# Altium schematic sheet Sheet1.SchDoc(Sheet1)
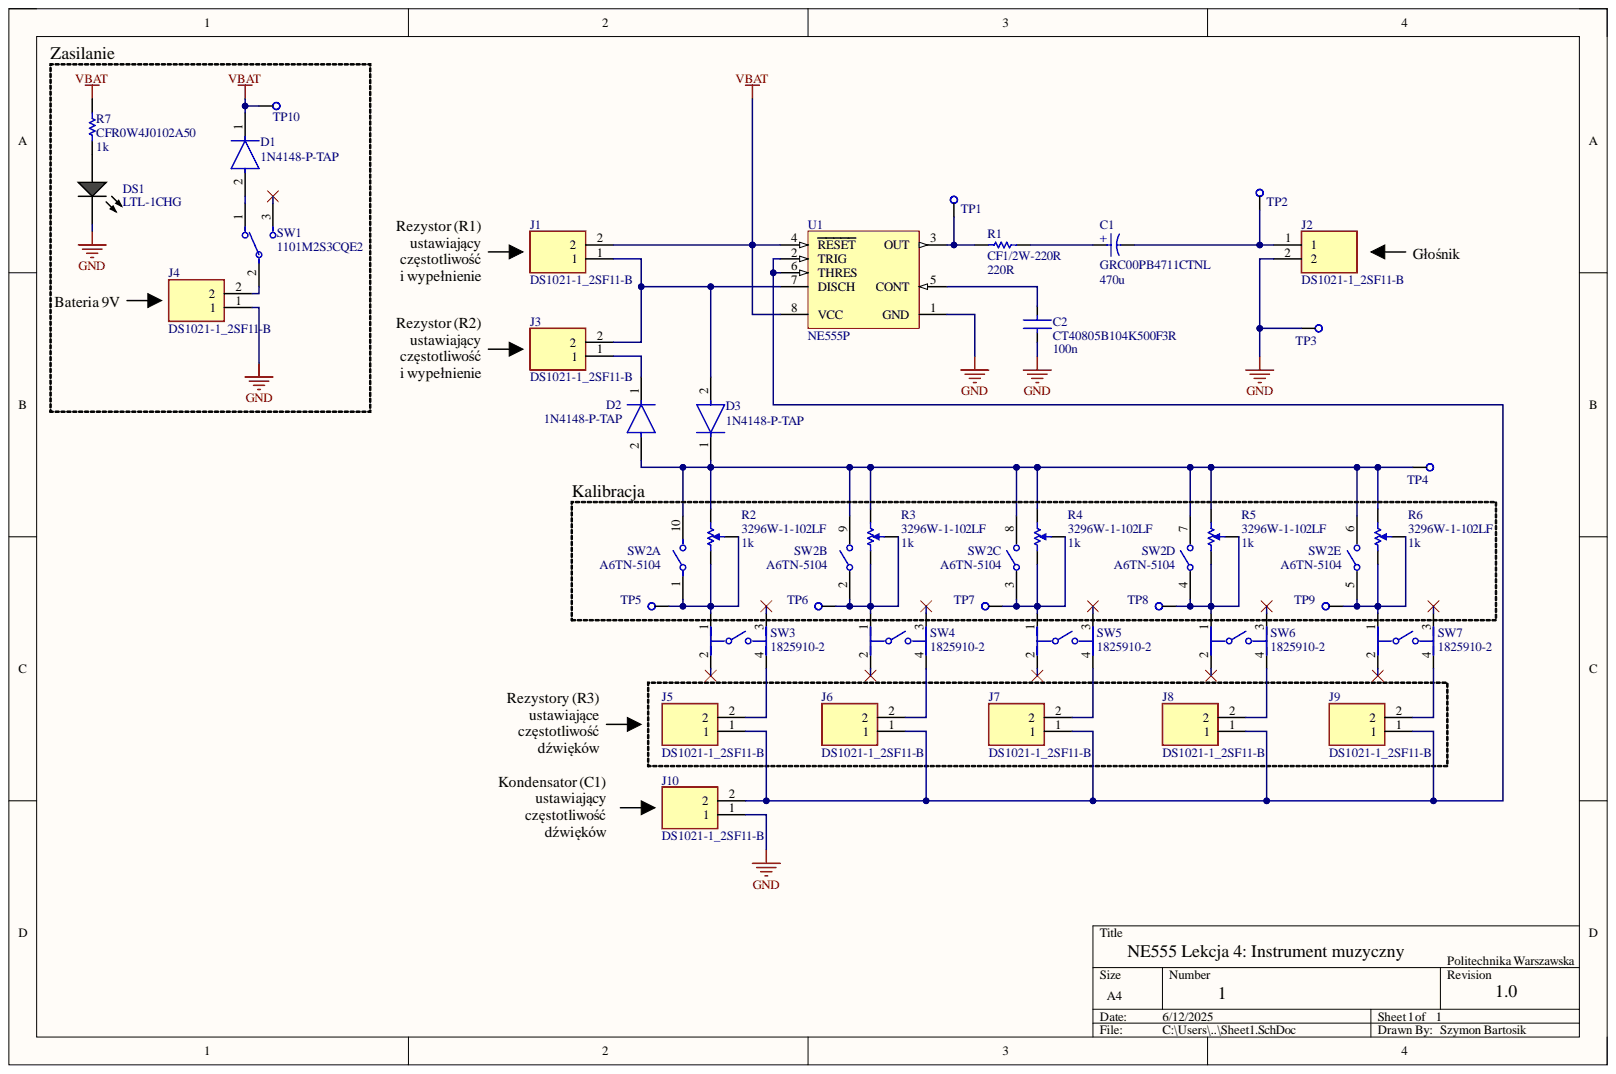
[diagram p0: Sheet1.SchDoc]

## Parts
C1  GRC00PB4711CTNL  Capacitance: 470u  [THT_Radial_Cap_D8.0mm_H11.5mm_P3.5mm]
C2  CT40805B104K500F3R  Capacitance: 100n  [THT_RADIAL_CAP_P5.0mm]
D1  1N4148-P-TAP  [FP-SB-V-3906_04-031_4-MFG]
D2  1N4148-P-TAP  [FP-SB-V-3906_04-031_4-MFG]
D3  1N4148-P-TAP  [FP-SB-V-3906_04-031_4-MFG]
DS1  LTL-1CHG  [LTST-LTL-1CHG-2]
J1  DS1021-1_2SF11-B  [HDRV2W64P0X254_1X2_508X254X588P]
J2  DS1021-1_2SF11-B  [HDRV2W64P0X254_1X2_508X254X588P]
J3  DS1021-1_2SF11-B  [HDRV2W64P0X254_1X2_508X254X588P]
J4  DS1021-1_2SF11-B  [HDRV2W64P0X254_1X2_508X254X588P]
J5  DS1021-1_2SF11-B  [HDRV2W64P0X254_1X2_508X254X588P]
J6  DS1021-1_2SF11-B  [HDRV2W64P0X254_1X2_508X254X588P]
J7  DS1021-1_2SF11-B  [HDRV2W64P0X254_1X2_508X254X588P]
J8  DS1021-1_2SF11-B  [HDRV2W64P0X254_1X2_508X254X588P]
J9  DS1021-1_2SF11-B  [HDRV2W64P0X254_1X2_508X254X588P]
J10  DS1021-1_2SF11-B  [HDRV2W64P0X254_1X2_508X254X588P]
R1  CF1/2W-220R  Resistance: 220R  [THT_AXIAL_RES_D3.2mm_L9.0mm]
R2  3296W-1-102LF  Resistance: 1k  [BOUR-3296W-1-3]
R3  3296W-1-102LF  Resistance: 1k  [BOUR-3296W-1-3]
R4  3296W-1-102LF  Resistance: 1k  [BOUR-3296W-1-3]
R5  3296W-1-102LF  Resistance: 1k  [BOUR-3296W-1-3]
R6  3296W-1-102LF  Resistance: 1k  [BOUR-3296W-1-3]
R7  CFR0W4J0102A50  Resistance: 1k  [THT_AXIAL_RES_D2.5mm_L6.8mm]
SW1  1101M2S3CQE2  [FP-1101M2S3CQE2-MFG]
SW2  A6TN-5104  [FP-A6TN-5104-MFG]  (parts A,B,C,D,E)
SW3  1825910-2  [FP-1825910-2-0]
SW4  1825910-2  [FP-1825910-2-0]
SW5  1825910-2  [FP-1825910-2-0]
SW6  1825910-2  [FP-1825910-2-0]
SW7  1825910-2  [FP-1825910-2-0]
TP1  Testpoint  [TESTPOINT_PAD_D2.5mm]  (value not drawn)  (no BOM)
TP2  Testpoint  [TESTPOINT_PAD_D2.5mm]  (value not drawn)  (no BOM)
TP3  Testpoint  [TESTPOINT_PAD_D2.5mm]  (value not drawn)  (no BOM)
TP4  Testpoint  [TESTPOINT_PAD_D2.5mm]  (value not drawn)  (no BOM)
TP5  Testpoint  [TESTPOINT_PAD_D2.5mm]  (value not drawn)  (no BOM)
TP6  Testpoint  [TESTPOINT_PAD_D2.5mm]  (value not drawn)  (no BOM)
TP7  Testpoint  [TESTPOINT_PAD_D2.5mm]  (value not drawn)  (no BOM)
TP8  Testpoint  [TESTPOINT_PAD_D2.5mm]  (value not drawn)  (no BOM)
TP9  Testpoint  [TESTPOINT_PAD_D2.5mm]  (value not drawn)  (no BOM)
TP10  Testpoint  [TESTPOINT_PAD_D2.5mm]  (value not drawn)  (no BOM)
U1  NE555P  [P0008A]

## Nets
GND: C2.1 DS1.2 J2.2 J4.1 J10.1 TP3.1 U1.1(GND)
VBAT: D1.1 J1.2 R7.1 TP10.1 U1.4(RESET) U1.8(VCC)
NetC1_1: C1.1 J2.1 TP2.1
NetC1_2: C1.2 R1.2
NetC2_2: C2.2 U1.5(CONT)
NetD1_2: D1.2 SW1.1
NetD2_1: D2.1 J3.1
NetD2_2: D2.2 D3.1 R2.2 R3.2 R4.2 R5.2 R6.2 SW2.6 SW2.7 SW2.8 SW2.9 SW2.10 TP4.1
NetD3_2: D3.2 J1.1 J3.2 U1.7(DISCH)
NetDS1_1: DS1.1 R7.2
NetJ4_2: J4.2 SW1.2
NetJ5_1: J5.1 J6.1 J7.1 J8.1 J9.1 J10.2 U1.2(TRIG) U1.6(THRES)
NetJ5_2: J5.2 SW3.4
NetJ6_2: J6.2 SW4.4
NetJ7_2: J7.2 SW5.4
NetJ8_2: J8.2 SW6.4
NetJ9_2: J9.2 SW7.4
NetR1_1: R1.1 TP1.1 U1.3(OUT)
NetR2_1: R2.1 R2.3 SW2.1 SW3.1 TP5.1
NetR3_1: R3.1 R3.3 SW2.2 SW4.1 TP6.1
NetR4_1: R4.1 R4.3 SW2.3 SW5.1 TP7.1
NetR5_1: R5.1 R5.3 SW2.4 SW6.1 TP8.1
NetR6_1: R6.1 R6.3 SW2.5 SW7.1 TP9.1

Not connected: SW1 3; SW3 2 3; SW4 2 3; SW5 2 3; SW6 2 3; SW7 2 3

## Library data of the parts
C1 = C-THT-RADIAL-POL-D8-H11.5-P3.5: Electrolytic Capacitor 470uF, 16V; Manufacturer: Vishay; Component Kind: Standard; Library Name: Common.IntLib; Voltage: 16V
C2 = C-THT-MLCC-5mm: Manufacturer: SR PASSIVES; Voltage: 50V; Component Kind: Standard; Library Name: Common.IntLib
D1-D3 = CMP-00030-43969657-1: 1N4148-P-TAP Switching Diode Genpurp Do35100V, 1V@10Ma, 4Ns, Ifmax=300Ma; Average Rectified Current: 2A; Capacitance: 4pF; Element Configuration: Single; Forward Current: 150mA; Forward Voltage: 1V; Max Junction Temperature (Tj): 175°C; Max Power Dissipation: 500mW; Max Repetitive Reverse Voltage (Vrrm): 100V; Max Reverse Leakage Current: 5µA; Max Reverse Voltage (DC): 75V; Recovery Time: 8ns; Case/Package: DO-35-2; Component Kind: Standard; Contact Plating: Tin; Height: 1.7mm; Library Name: Altium Content Vault; Max Forward Surge Current (Ifsm): 2A; Max Operating Temperature: 175°C; Max Surge Current: 2A; Min Operating Temperature: -65°C; Mount: Through Hole; NexarPartId: 43969657; Number of Pins: 2; Peak Non-Repetitive Surge Current: 2A; Peak Reverse Current: 5µA; Reverse Recovery Time: 8ns; Reverse Voltage: 75V; RoHS: Compliant; Schedule B: 8541100070, 8541100070
DS1 = CMP-1674-00014-1: LITE-ON Electronic, INC, 120 mA, 100 mW, 5 V, -55 to 100 degC, 2-Pin THD, RoHS, Bulk; Case\Package: QFN; Interface: I2C; Max Supply Voltage: 3.6 V; Min Supply Voltage: 2.5 V; Component Kind: Standard; ComponentLink1Description: Manufacturer URL; ComponentLink1URL: http://www.us.liteon.com/; ComponentLink2Description: Datasheet; ComponentLink2URL: http://datasheet.octopart.com/LTL-1CHG-Lite-On-datasheet-143841.pdf; DatasheetVersion: Mar-00; Library Name: Altium Content Vault; Max Operating Temperature: 85 degC; Min Operating Temperature: -40 degC; Package Quantity: 5000; PackageDescription: 2-Pin Through Hole Device, Dia 3.8 mm; PackageReference: LTL-1CHG; Packaging: Cut Tape; Pins: 24; REACH SVHC: No SVHC; RoHSCompliant: true
J1-J10 = DS1021-1_2SF11-B: Connector; Manufacturer: Connfly; Part Number: DS1021-1*2SF11-B; Component Kind: Standard; Library Name: Common.IntLib
R1 = R-THT-500mW: Manufacturer: SR PASSIVES; Power: 500mW; Component Kind: Standard; Library Name: Common.IntLib
R2-R6 = CMP-1747-00002-1: Square Trimpot(R) Trimming Potentiometer, 1000 Ohm, -55 to 125 degC, 3-Pin THD, RoHS, Tube; Case\Package: 0603; Power Rating: 100 mW; Tolerance: 1%; Voltage Rating: 75 V; Case Code (Imperial): 0603; Case Code (Metric): 1608; Component Kind: Standard; ComponentLink1Description: Manufacturer URL; ComponentLink1URL: http://www.bourns.com/; ComponentLink2Description: Datasheet; ComponentLink2URL: http://www.bourns.com/docs/Product-Datasheets/3296.pdf; DatasheetVersion: May-16; Height: 0.45 mm; Length: 1.6 mm; Library Name: Altium Content Vault; Max Operating Temperature: 155 degC; Min Operating Temperature: -55 degC; Mount: Surface Mount; Package Quantity: 5000; PackageDescription: 3-Pin Through Hole Device, Body 9.53 x 4.83 mm, Pitch 2.54 mm; PackageReference: 3296W-1-3; Packaging: Tape and Reel; Pins: 2; Radiation Hardening: No; REACH SVHC: Yes; RoHSCompliant: true; Weight: 7.1E-05 oz; Width: 0.8 mm
R7 = R-THT-250mW: Manufacturer: ROYALOHM; Power: 250mW; Component Kind: Standard; Library Name: Common.IntLib
SW1 = CMP-10038-000019-1: SWITCH SLIDE SPDT 6A 120V; Actuator Color: Black; Actuator Length: 5.08mm; Actuator Material: Nylon; Actuator Type: Standard; Approvals: UL; Body Material: Stainless Steel; Contact Resistance: 10mR; Electrical Life: 40000Cycles; Insulation Resistance: 1GR; Max Voltage Rating (AC): 250V; Max Voltage Rating (DC): 28V; Number of Poles: 1; Number of Positions: 3; Switch Function: On-On; Switch Travel: 2.16mm; Component Kind: Standard; Contact Current Rating: 6A; Contact Material: Copper; Contact Plating: Silver; Current Rating: 6A; Depth: 6.6mm; Flammability Rating: UL94 V-0; Housing Material: Stainless Steel; Lead Free: Lead Free; Length: 12.7mm; Library Name: Altium Content Vault; Max Current Rating: 6A; Max Operating Temperature: 65°C; Min Operating Temperature: -30°C; Mount: Through Hole; Orientation: Vertical; Package Quantity: 1; Packaging: Bulk; REACH SVHC: No SVHC; Series: 1000; Termination: PC Pins; Throw Configuration: SPDT; Voltage Rating (AC): 120V; Voltage Rating (DC): 28V
SW2 = CMP-08827-000038-1: SWITCH SLIDE DIP SPST 25MA 24V; Actuator Length: 1mm; Actuator Type: Slide (Standard); Contact Resistance: 200mR; Electrical Life: 1000Cycles; Number of Circuits: 5; Pitch: 0.1inch; Washable: Yes; Component Kind: Standard; Current Rating: 25mA; Length: 0.576inch; Library Name: Altium Content Vault; Max Operating Temperature: 85°C; Max Voltage Rating (DC): 24V; Min Operating Temperature: -30°C; Mount: Through Hole; Number of Positions: 5; Orientation: Straight; Package Quantity: 30; Packaging: Rail/Tube; Radiation Hardening: No; RoHS Compliant: Yes; Series: A6TN; Terminal Pitch: 2.54mm; Termination: PC Pins; Throw Configuration: SPST; Voltage Rating (DC): 24V
SW3-SW7 = CMP-03407-000004-1: SWITCH TACTILE SPST-NO 0.05A 24V; Manufacturer: TE; Contact Current Rating: 50mA; Contact Resistance: 100mΩ; Electromechanical Life: 100000Cycles; Operating Force: 160gf; Automotive: No; Category: Switch; Component Kind: Standard; ComponentLink2Description: Datasheet; ComponentLink2URL: https://www.te.com/commerce/DocumentDelivery/DDEController?Action=showdoc&DocId=Customer+Drawing%7F1825910%7FC10%7Fpdf%7FEnglish%7FENG_CD_1825910_C10.pdf%7F1825910-2; Device Class L1: Electromechanical; Device Class L2: Switches; Device Class L3: Tactile Switches; Digikey Description: SWITCH TACTILE SPST-NO 0.05A 24V; Height: 4.6mm; Lead Free: Yes; Library Name: Altium Content Vault; Manufacturer Part Number: 1825910-2; Mount: Through Hole; Octopart: https://octopart.com/1825910-2-te+connectivity-42270502; Package: 0; RoHS: TRUE; Temperature: -35°C to +85°C; Temperature Range High: +85°C; Temperature Range Low: -35°C; Throw Configuration: SPST-NO; Voltage Rating Dc: 24V
TP1-TP10 = Testpoint-D1.5mm: Library Name: Common.IntLib
U1 = CMP-2000-04954-1: Precision Timer, 4.5 to 16 V, 0 to 70 degC, 8-Pin DIP, Pb-Free, Tube; Manufacturer: TexasInstruments; Maximum High Level Output Current: -200mA; Maximum Low Level Output Current: 200mA; Number of Internal Timers: 1; Operating Supply Voltage: 5; Rad Hard: No; Category: Timer; Component Kind: Standard; Datasheet URL: http://www.ti.com/general/docs/lit/getliterature.tsp?genericPartNumber=NE555&fileType=pdf; Datasheet Version: Rev. I, 09/2014; Library Name: Altium Content Vault; Manufacturer URL: http://www.ti.com/; Package: 8PDIP; Package Description: 8-Pin Double In-line Package, Body 9.59 x 6.35 mm, Pitch 2.54 mm; Package Reference: P8; Package URL: http://www.ti.com/lit/ml/mpdi001b/mpdi001b.pdf; Packaging: Rail/Tube; Type: Standard
